# Altium schematic sheet sch.SchDoc
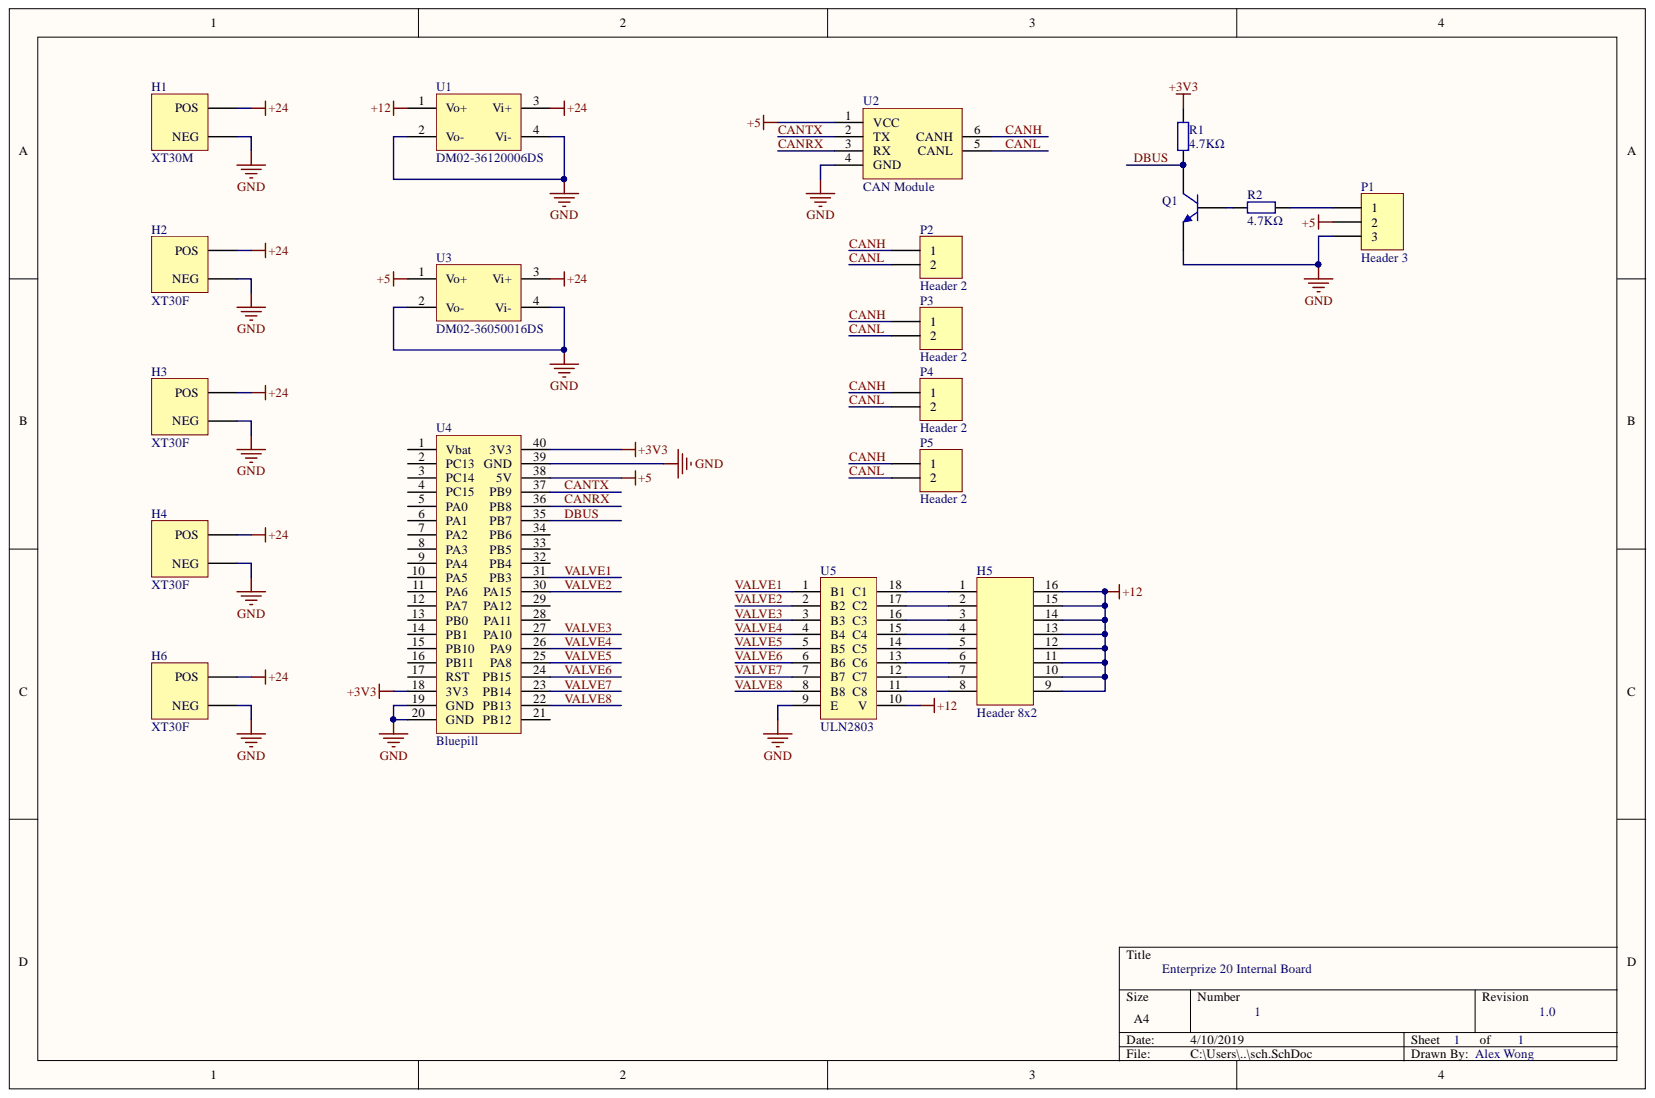
[diagram p0: sch.SchDoc]

## Parts
H1  XT30M  [XT30-PW - no anc]
H2  XT30F  [XT30-PW - no anc]
H3  XT30F  [XT30-PW - no anc]
H4  XT30F  [XT30-PW - no anc]
H5  Header 8x2
H6  XT30F  [XT30-PW - no anc]
P1  Header 3  [HDR1X3]
P2  Header 2  [HDR1X2]
P3  Header 2  [HDR1X2]
P4  Header 2  [HDR1X2]
P5  Header 2  [HDR1X2]
Q1  2N3904  [TO-92A]  (value not drawn)
R1  4.7KΩ  [0805_R]
R2  4.7KΩ  [0805_R]
U1  DM02-36120006DS
U2  CAN Module
U3  DM02-36050016DS
U4  Bluepill
U5  ULN2803

## Nets
+3V3: R1.2 U4.18(3V3) U4.40(3V3)
+5: P1.2 U2.1(VCC) U3.1(Vo+) U4.38(5V)
+12: H5.9 H5.10 H5.11 H5.12 H5.13 H5.14 H5.15 H5.16 U1.1(Vo+) U5.10(V)
+24: H1.POS H2.POS H3.POS H4.POS H6.POS U1.3(Vi+) U3.3(Vi+)
GND: H1.NEG H2.NEG H3.NEG H4.NEG H6.NEG P1.3 Q1.1 U1.2(Vo-) U1.4(Vi-) U2.4(GND) U3.2(Vo-) U3.4(Vi-) U4.19(GND) U4.20(GND) U4.39(GND) U5.9(E)
CANH: P2.1 P3.1 P4.1 P5.1 U2.6(CANH)
CANL: P2.2 P3.2 P4.2 P5.2 U2.5(CANL)
CANRX: U2.3(RX) U4.36(PB8)
CANTX: U2.2(TX) U4.37(PB9)
DBUS: Q1.3 R1.1 U4.35(PB7)
VALVE1: U4.31(PB3) U5.1(B1)
VALVE2: U4.30(PA15) U5.2(B2)
VALVE3: U4.27(PA10) U5.3(B3)
VALVE4: U4.26(PA9) U5.4(B4)
VALVE5: U4.25(PA8) U5.5(B5)
VALVE6: U4.24(PB15) U5.6(B6)
VALVE7: U4.23(PB14) U5.7(B7)
VALVE8: U4.22(PB13) U5.8(B8)
NetH5_1: H5.1 U5.18(C1)
NetH5_2: H5.2 U5.17(C2)
NetH5_3: H5.3 U5.16(C3)
NetH5_4: H5.4 U5.15(C4)
NetH5_5: H5.5 U5.14(C5)
NetH5_6: H5.6 U5.13(C6)
NetH5_7: H5.7 U5.12(C7)
NetH5_8: H5.8 U5.11(C8)
NetP1_1: P1.1 R2.2
NetQ1_2: Q1.2 R2.1

Not connected: U4 1(Vbat) 2(PC13) 3(PC14) 4(PC15) 5(PA0) 6(PA1) 7(PA2) 8(PA3) 9(PA4) 10(PA5) 11(PA6) 12(PA7) 13(PB0) 14(PB1) 15(PB10) 16(PB11) 17(RST) 21(PB12) 28(PA11) 29(PA12) 32(PB4) 33(PB5) 34(PB6)

## Library data of the parts
H1-H4 H6 = XTx0: Component Kind: Standard; Library Name: alexLib.SchLib
P1 = Header 3: Header, 3-Pin; Component Kind: Standard; LatestRevisionDate: 17-Jul-2002; LatestRevisionNote: Re-released for DXP Platform.; Library Name: Miscellaneous Connectors.IntLib; Publisher: Altium Limited
P2-P5 = Header 2: Header, 2-Pin; Component Kind: Standard; LatestRevisionDate: 17-Jul-2002; LatestRevisionNote: Re-released for DXP Platform.; Library Name: Miscellaneous Connectors.IntLib; Publisher: Altium Limited
Q1 = 2N3904: NPN General Purpose Amplifier; Component Kind: Standard; ComponentLink1Description: Manufacturer Link; ComponentLink1URL: http://www.fairchildsemi.com/; ComponentLink2Description: Datasheet; ComponentLink2URL: http://www.fairchildsemi.com/ds/2N%2F2N3904.pdf; DatasheetVersion: 1997; LatestRevisionDate: 15-Jan-2003; LatestRevisionNote: PCB Footprint 'BCY-W3/D4.7' replaced; Library Name: Miscellaneous Devices.IntLib; PackageDescription: TO, Flat Index; 3 In-Line, Axial Leads; Body Dia. 4.5mm; Leads 0.51 x 0.48 mm (max); PackageReference: TO-92A; PackageVersion: Sep-1998; Published: 8-Jun-2000; Simulation: 2N3904; Publisher: Altium Limited
R1 R2 = 0805 4.7KΩ (4701) 1%: 贴片电阻; Component Kind: Standard; ComponentLink1Description: 供应商链接; ComponentLink1URL: http://www.szlcsc.com/product/details_18361.html; Library Name: RES.SchLib; Package: 0805; Supplier: LC; SuppliersPartNumber: C17673
U1 U3 = Buck Reg Module: Component Kind: Standard; Library Name: alexLib.SchLib
H5 = Header 8x2: Component Kind: Standard; Library Name: alexLib.SchLib
U2 = CAN Module: Component Kind: Standard; Library Name: alexLib.SchLib
U4 = Bluepill: Component Kind: Standard; Library Name: alexLib.SchLib
U5 = ULN2803: Component Kind: Standard; Library Name: alexLib.SchLib
